# Altium schematic sheet Sheet2.SchDoc
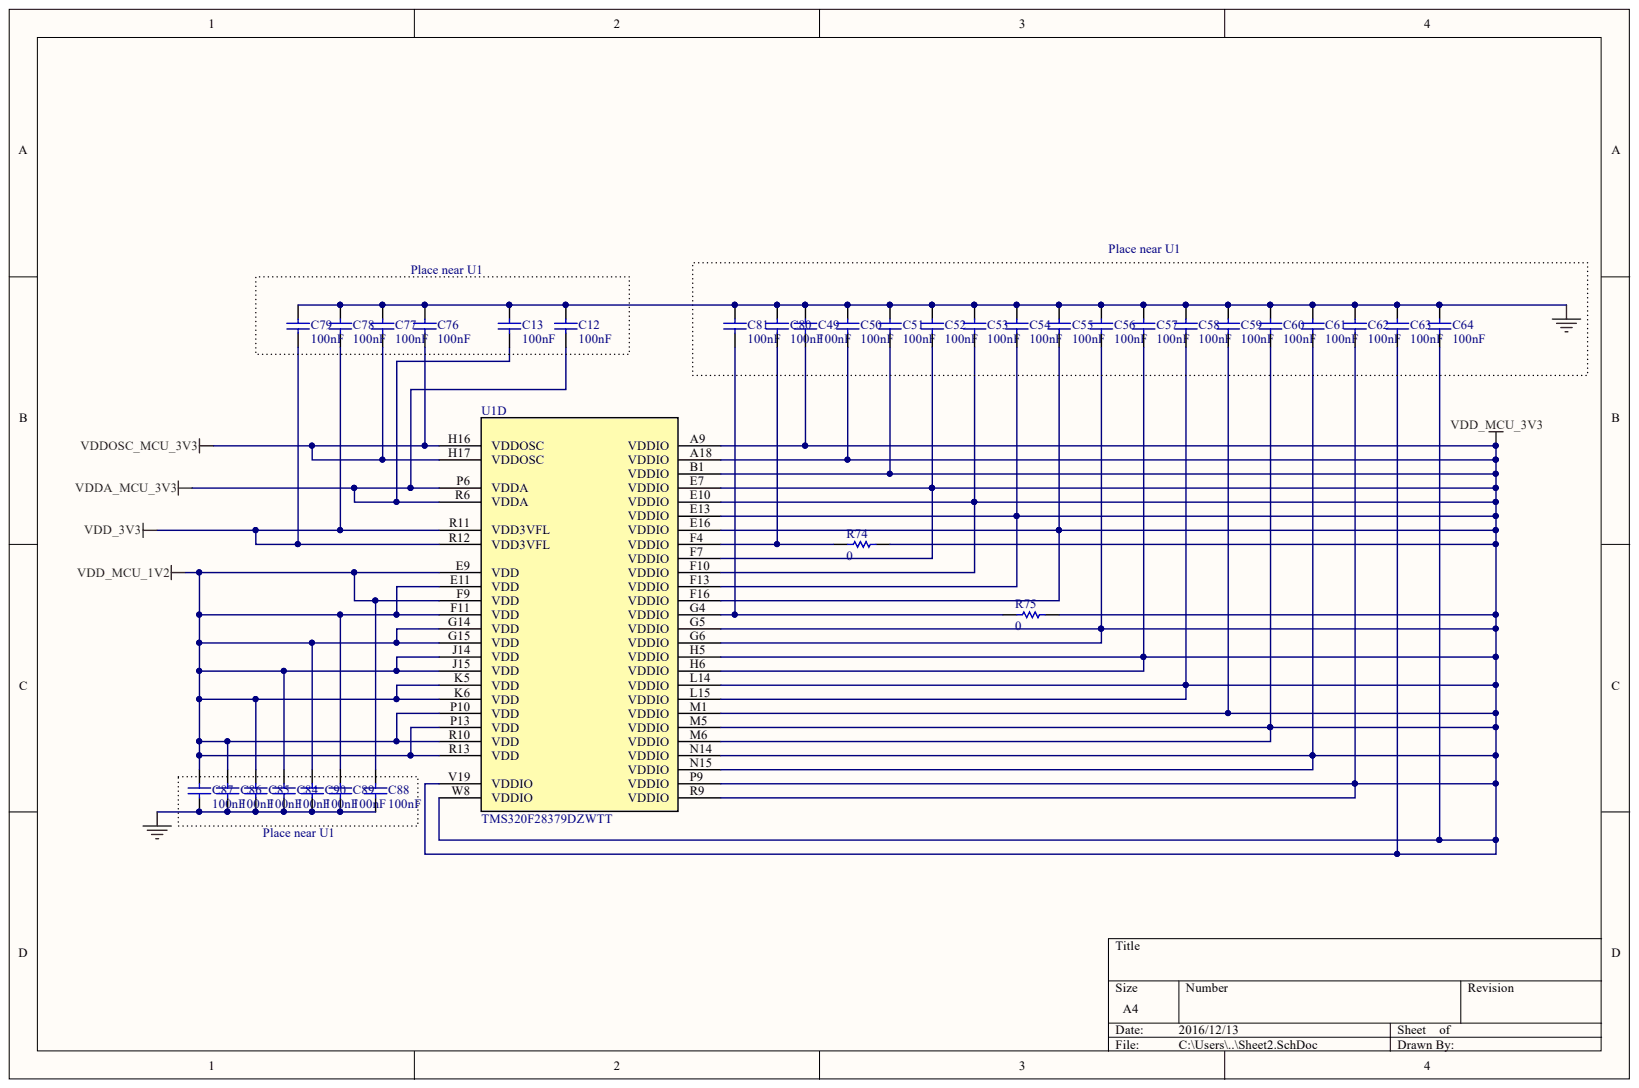
[diagram p0: Sheet2.SchDoc]

## Parts
C12  value 100nF  [0805_TW]  (hidden comment: C_0805)
C13  value 100nF  [0805_TW]  (hidden comment: C_0805)
C49  value 100nF  [0805_TW]  (hidden comment: C_0805)
C50  value 100nF  [0805_TW]  (hidden comment: C_0805)
C51  value 100nF  [0805_TW]  (hidden comment: C_0805)
C52  value 100nF  [0805_TW]  (hidden comment: C_0805)
C53  value 100nF  [0805_TW]  (hidden comment: C_0805)
C54  value 100nF  [0805_TW]  (hidden comment: C_0805)
C55  value 100nF  [0805_TW]  (hidden comment: C_0805)
C56  value 100nF  [0805_TW]  (hidden comment: C_0805)
C57  value 100nF  [0805_TW]  (hidden comment: C_0805)
C58  value 100nF  [0805_TW]  (hidden comment: C_0805)
C59  value 100nF  [0805_TW]  (hidden comment: C_0805)
C60  value 100nF  [0805_TW]  (hidden comment: C_0805)
C61  value 100nF  [0805_TW]  (hidden comment: C_0805)
C62  value 100nF  [0805_TW]  (hidden comment: C_0805)
C63  value 100nF  [0805_TW]  (hidden comment: C_0805)
C64  value 100nF  [0805_TW]  (hidden comment: C_0805)
C76  value 100nF  [0805_TW]  (hidden comment: C_0805)
C77  value 100nF  [0805_TW]  (hidden comment: C_0805)
C78  value 100nF  [0805_TW]  (hidden comment: C_0805)
C79  value 100nF  [0805_TW]  (hidden comment: C_0805)
C80  value 100nF  [0805_TW]  (hidden comment: C_0805)
C81  value 100nF  [0805_TW]  (hidden comment: C_0805)
C84  value 100nF  [0805_TW]  (hidden comment: C_0805)
C85  value 100nF  [0805_TW]  (hidden comment: C_0805)
C86  value 100nF  [0805_TW]  (hidden comment: C_0805)
C87  value 100nF  [0805_TW]  (hidden comment: C_0805)
C88  value 100nF  [0805_TW]  (hidden comment: C_0805)
C89  value 100nF  [0805_TW]  (hidden comment: C_0805)
C90  value 100nF  [0805_TW]  (hidden comment: C_0805)
R74  value 0  [0402_TW]  (hidden comment: R_0402)
R75  value 0  [0402_TW]  (hidden comment: R_0402)
U1  TMS320F28379DZWTT  [ZWT0337A]  (part D)

## Nets
GND: C12.2 C13.2 C49.2 C50.2 C51.2 C52.2 C53.2 C54.2 C55.2 C56.2 C57.2 C58.2 C59.2 C60.2 C61.2 C62.2 C63.2 C64.2 C76.2 C77.2 C78.2 C79.2 C80.2 C81.2 C84.1 C85.1 C86.1 C87.1 C88.1 C89.1 C90.1
VDD_3V3: C78.1 C79.1 U1.R11(VDD3VFL) U1.R12(VDD3VFL)
VDD_MCU_1V2: C84.2 C85.2 C86.2 C87.2 C88.2 C89.2 C90.2 U1.E9(VDD) U1.E11(VDD) U1.F9(VDD) U1.F11(VDD) U1.G14(VDD) U1.G15(VDD) U1.J14(VDD) U1.J15(VDD) U1.K5(VDD) U1.K6(VDD) U1.P10(VDD) U1.P13(VDD) U1.R10(VDD) U1.R13(VDD)
VDD_MCU_3V3: C49.1 C50.1 C51.1 C52.1 C53.1 C54.1 C55.1 C56.1 C57.1 C58.1 C59.1 C60.1 C61.1 C62.1 C63.1 C64.1 R74.2 R75.2 U1.A9(VDDIO) U1.A18(VDDIO) U1.B1(VDDIO) U1.E7(VDDIO) U1.E10(VDDIO) U1.E13(VDDIO) U1.E16(VDDIO) U1.F7(VDDIO) U1.F10(VDDIO) U1.F13(VDDIO) U1.F16(VDDIO) U1.G5(VDDIO) U1.G6(VDDIO) U1.H5(VDDIO) U1.H6(VDDIO) U1.L14(VDDIO) U1.L15(VDDIO) U1.M1(VDDIO) U1.M5(VDDIO) U1.M6(VDDIO) U1.N14(VDDIO) U1.N15(VDDIO) U1.P9(VDDIO) U1.R9(VDDIO) U1.V19(VDDIO) U1.W8(VDDIO)
VDDA_MCU_3V3: C12.1 C13.1 U1.P6(VDDA) U1.R6(VDDA)
VDDOSC_MCU_3V3: C76.1 C77.1 U1.H16(VDDOSC) U1.H17(VDDOSC)
NetC80_1: C80.1 R74.1 U1.F4(VDDIO)
NetC81_1: C81.1 R75.1 U1.G4(VDDIO)

## Library data of the parts
U1 = TMS320F28379DZWTT: Imported; Manufacturer: Texas Instruments; Component Kind: Standard; Library Name: TMS320F28379DZWTT.SchLib; PartNumber: TMS320F28379DZWTT; RefDes: RefDes; Type: DEV; Value: Value
C12 C13 C49-C64 C76-C81 C84-C90 = C_0805: Component Kind: Standard; Library Name: TW_Lib.SchLib
R74 R75 = R_0402: Component Kind: Standard; Library Name: TW_Lib.SchLib
